# Altium schematic sheet Master.SchDoc(Master)
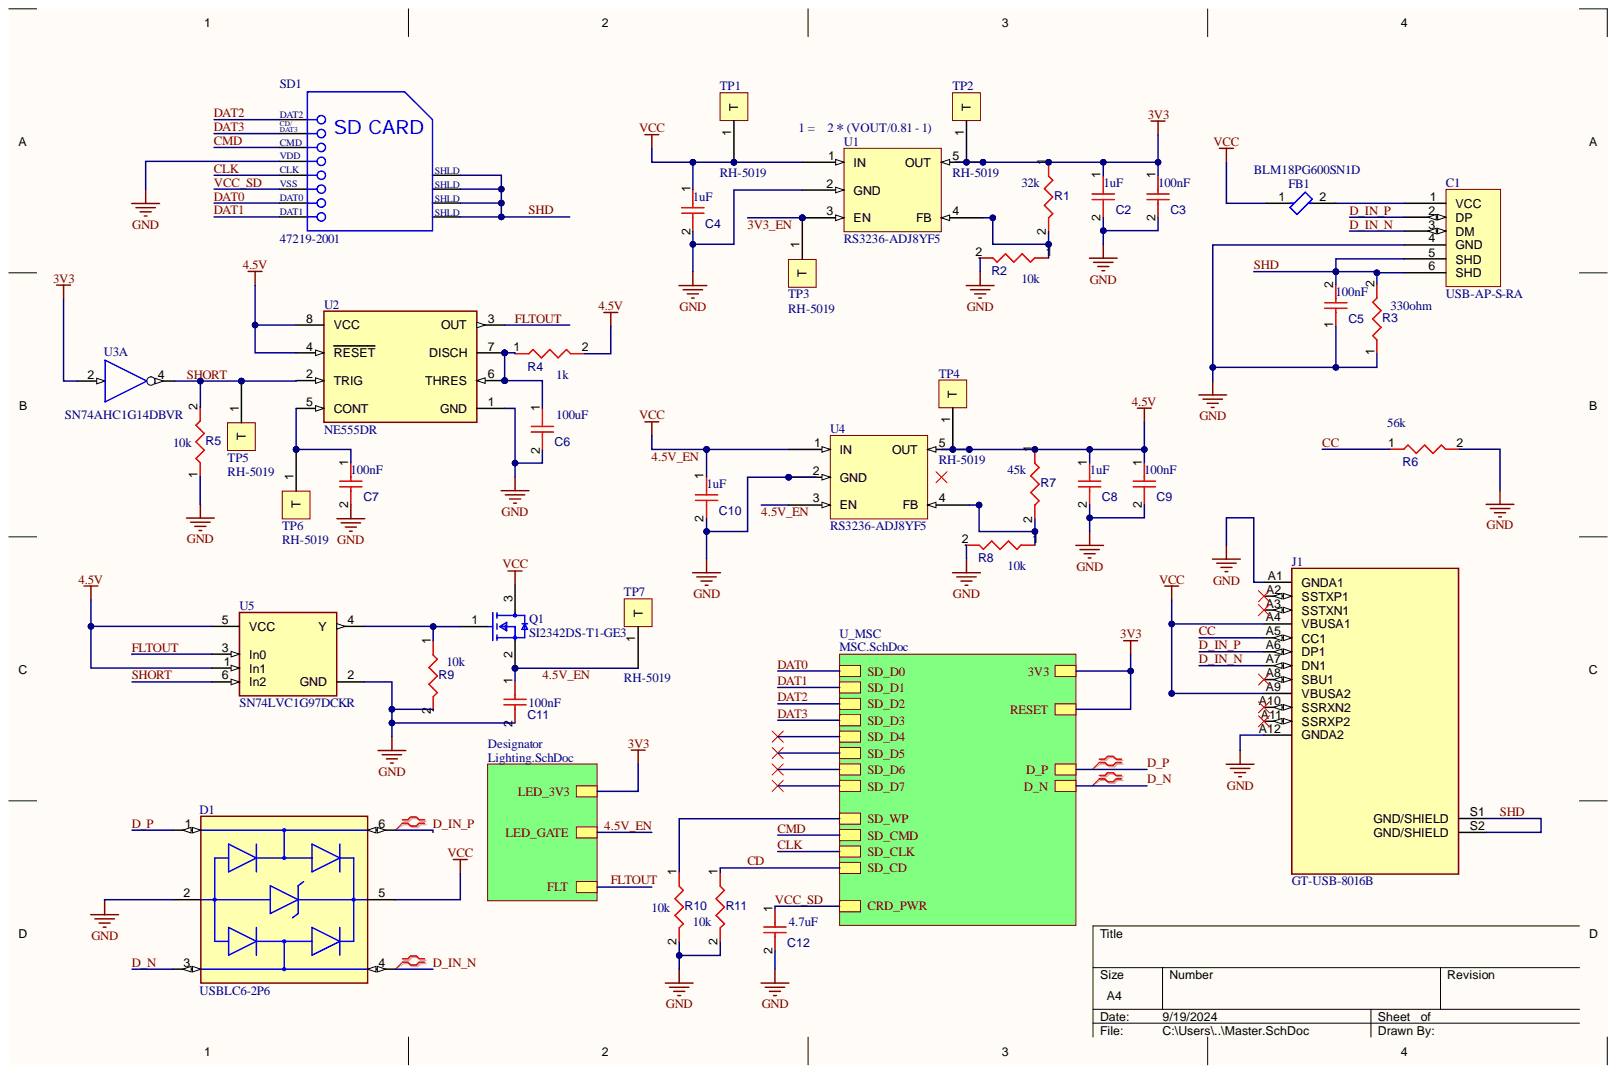
[diagram p0: Master.SchDoc]

## Parts
C1  USB-AP-S-RA
C2  value 1uF  (hidden comment: Capacitors,1uF)
C3  value 100nF  (hidden comment: Capacitors,100nF)
C4  value 1uF  (hidden comment: Capacitors,1uF)
C5  value 100nF  (hidden comment: Capacitors)
C6  value 100uF  (hidden comment: Capacitors,100uF)
C7  value 100nF  (hidden comment: Capacitors,100nF)
C8  value 1uF  (hidden comment: Capacitors,1uF)
C9  value 100nF  (hidden comment: Capacitors,100nF)
C10  value 1uF  (hidden comment: Capacitors,1uF)
C11  value 100nF  (hidden comment: Capacitors,100nF)
C12  value 4.7uF  (hidden comment: Capacitors,4.7uF)
D1  USBLC6-2P6  [FP-SOT-666-MFG]
FB1  BLM18PG600SN1D  [FP-BLM18-0_15-t0_8-IPC_B]
J1  GT-USB-8016B  [BELFUSE_SS-52400-002]
Q1  SI2342DS-T1-GE3  [FP-S-03946-MFG]
R1  value 32k  (hidden comment: Resistors,32k)
R2  value 10k  (hidden comment: Resistors,10k)
R3  value 330ohm  (hidden comment: Resistors)
R4  value 1k  (hidden comment: Resistors,1k)
R5  value 10k  (hidden comment: Resistors,10k)
R6  value 56k  (hidden comment: Resistors,56k)
R7  value 45k  (hidden comment: Resistors,32k)
R8  value 10k  (hidden comment: Resistors,10k)
R9  value 10k  (hidden comment: Resistors,10k)
R10  value 10k  (hidden comment: Resistors,10k)
R11  value 10k  (hidden comment: Resistors,10k)
SD1  47219-2001  [MOLX-47219-2001-8_V]
TP1  RH-5019  [RH-5019-Footprint-1]
TP2  RH-5019  [RH-5019-Footprint-1]
TP3  RH-5019  [RH-5019-Footprint-1]
TP4  RH-5019  [RH-5019-Footprint-1]
TP5  RH-5019  [RH-5019-Footprint-1]
TP6  RH-5019  [RH-5019-Footprint-1]
TP7  RH-5019  [RH-5019-Footprint-1]
U1  RS3236-ADJ8YF5  [SOT95P245X110-5N]
U2  NE555DR  [FP-D0008A-IPC_A]
U3  SN74AHC1G14DBVR  [DBV0005A_L]  (part A)
U4  RS3236-ADJ8YF5  [SOT95P245X110-5N]
U5  SN74LVC1G97DCKR  [FP-DCK0006A-MFG]

## Nets
3V3: C2.1 C3.1 R1.1 TP2.1 U1.5(OUT) U3.2
4.5V: C8.1 C9.1 R4.2 R7.1 TP4.1 U2.4(RESET) U2.8(VCC) U4.5(OUT) U5.1(In1) U5.5(VCC)
GND: C1.4(GND) C2.2 C3.2 C4.2 C5.1 C6.2 C7.2 C8.2 C9.2 C10.2 C11.2 C12.2 D1.2 J1.A1(GNDA1) J1.A12(GNDA2) R2.2 R3.1 R5.1 R6.2 R8.2 R9.2 R10.2 R11.2 SD1.4 U1.2(GND) U2.1(GND) U4.2(GND) U5.2(GND)
3V3_EN: TP3.1 U1.3(EN)
4.5V_EN: C4.1 C10.1 C11.1 D1.5 FB1.1 J1.A4(VBUSA1) J1.A9(VBUSA2) Q1.2 Q1.3 TP1.1 TP7.1 U1.1(IN) U4.1(IN) U4.3(EN)
CC: J1.A5(CC1) R6.1
CD: R11.1
CLK: SD1.5
CMD: SD1.3
D_IN_N: C1.3(DM) D1.4 J1.A7(DN1)
D_IN_P: C1.2(DP) D1.6 J1.A6(DP1)
D_N: D1.3
D_P: D1.1
DAT0: SD1.7
DAT1: SD1.8
DAT2: SD1.1
DAT3: SD1.2(SD_CARD)
FLTOUT: U2.3(OUT) U5.3(In0)
SHD: C1.5(SHD) C1.6(SHD) C5.2 J1.S1(GND/SHIELD) J1.S2(GND/SHIELD) R3.2 SD1.9 SD1.10 SD1.11 SD1.12
SHORT: R5.2 TP5.1 U2.2(TRIG) U3.4 U5.6(In2)
VCC_SD: C12.1 SD1.6
NetC1_1: C1.1(VCC) FB1.2
NetC6_1: C6.1 R4.1 U2.6(THRES) U2.7(DISCH)
NetC7_1: C7.1 TP6.1 U2.5(CONT)
NetJ1_A2: J1.A2(SSTXP1)
NetJ1_A3: J1.A3(SSTXN1)
NetJ1_A8: J1.A8(SBU1)
NetJ1_A10: J1.A10(SSRXN2)
NetJ1_A11: J1.A11(SSRXP2)
NetQ1_1: Q1.1 R9.1 U5.4(Y)
NetR1_2: R1.2 R2.1 U1.4(FB)
NetR7_2: R7.2 R8.1 U4.4(FB)
NetR10_1: R10.1
SD_D4:
SD_D5:
SD_D6:
SD_D7:

## Library data of the parts
C1 = CMP-002-00072-1: USB Type A Plug Single R/A PCB; Component Kind: Standard; Library Name: Brian Tan
C2-C12 = Capacitors: Capacitor; Component Kind: Standard; Component Type: Capacitors; Library Name: Brian Tan
D1 = CMP-12225-000058-1: TVS DIODE 5.25V 17V SOT666; Breakdown Voltage: 6V; Capacitance: 3.5pF; Direction: Unidirectional; Element Configuration: Dual; ESD Protection: Yes; Leakage Current: 150nA; Number of Bidirectional Channels: 2; Number of Elements: 1; Operating Supply Voltage: 5V; Peak Pulse Current: 5A; Test Current: 1mA; Case/Package: SOT-666; Clamping Voltage: 17V; Component Kind: Standard; Contact Plating: Tin; Depth: 1.3mm; Height: 0.6mm; Lead Free: Lead Free; Length: 1.7mm; Library Name: Altium Content Vault; Max Breakdown Voltage: 6V; Max Operating Temperature: 125°C; Max Reverse Leakage Current: 150nA; Min Breakdown Voltage: 6V; Min Operating Temperature: -40°C; Mount: Surface Mount; Number of Channels: 2; Number of Pins: 6; Package Quantity: 1; Packaging: Tape and Reel; Polarity: Unidirectional; Power Line Protection: Yes; Radiation Hardening: No; REACH SVHC: No SVHC; Reverse Breakdown Voltage: 6V; Reverse Standoff Voltage: 5V; Termination: SMD/SMT; Width: 1.3mm; Working Voltage: 5V
FB1 = CMP-0686-00575-3: Chip Ferrite Bead, 0603, 60Ω @ 100MHz, 0.1Ω, , 1A; Current Rating: 500mA; DC Resistance (DCR): 100mR; ESR (Equivalent Series Resistance): 60R; Material: Steel; Number of Circuits: 1; Shielding: Unshielded; Tolerance: 25%; Case Code (Imperial): 0603; Case Code (Metric): 1608; Case/Package: 0603; Component Kind: Standard; Core Material: Ferrite; Depth: 0.8mm; Frequency: 100MHz; Height: 0.8mm; Impedance: 60R; Lead Free: Lead Free; Length: 1.6mm; Library Name: Altium Content Vault; Max Current Rating: 500mA; Max DC Current: 500mA; Max Operating Temperature: 125°C; Min Operating Temperature: -55°C; Number of Lines: 1; Number of Pins: 2; Package Quantity: 1; Packaging: Tape and Reel; REACH SVHC: No SVHC; Resistance: 100mR; RoHS Compliant: Yes; Termination: SMD/SMT; Test Frequency: 100MHz; Width: 0.8mm
J1 = CMP-002-00071-2: USB C 2.0 Plug; Component Kind: Standard; Library Name: Brian Tan
Q1 = CMP-02550-000018-1: MOSFET N-CH 8V 6A SOT-23; Manufacturer: Vishay; Depletion Mode: False; Drain To Source Resistance: 0.014Ω; Gate Charge At Vgs: 10.5nC @ 4.5V; Gate To Source Voltage: 5V; Input Capacitace At Vds: 1070pF @ 4V; Max Forward Diode Voltage: 1.2V; Max Junction Temp: +150°C; Number Of Channels: 1; Power Dissipation: 1.3W; Pulse Drain Current: 30A; Reverse Recovery Charge: 17nC; Reverse Recovery Time: 40ns; Rthja Max: 75°C/W; Standoff Height: 0.01mm; Threshold Vgs Max: 0.8V; Threshold Vgs Min: 0.35V; Transconductance: 75S; Turn Off Delay Time: 65ns; Turn On Delay Time: 6ns; Typ Forward Diode Voltage: 0.82V; Automotive: No; Category: Trans; Component Kind: Standard; ComponentLink2Description: Datasheet; ComponentLink2URL: https://www.vishay.com/docs/63302/si2342ds.pdf; Continuous Drain Current: 6A; Device Class L1: Discrete Semiconductors; Device Class L2: Transistors; Device Class L3: MOSFETs; Digikey Description: MOSFET N-CH 8V 6A SOT-23; Drain To Source Breakdown Voltage: 8V; Drain To Source Voltage: 8V; Height: 1.12mm; Ipc Land Pattern Name: SOT95P237X100-3; Lead Free: Yes; Library Name: Altium Content Vault; Manufacturer Part Number: SI2342DS-T1-GE3; Mouser Description: MOSFET 8V 6A 2.5; Number Of N Channels: 1; Octopart: https://octopart.com/si2342ds-t1-ge3-vishay-45050957; Package: S-03946; RoHS: TRUE; Temperature: -55°C to +150°C; Temperature Range High: +150°C; Temperature Range Low: -55°C
R1-R11 = Resistors: Resistor; Component Kind: Standard; Component Type: Resistors; Library Name: Brian Tan
SD1 = CMP-2000-05245-1: MicroSD Card Connector, Hinge Type, -40 to 85 degC, 8-Pin SMD, RoHS, Tape and Reel; Brand: Molex; Current Rating: 500mA; Insertion - Extraction Style: Hinged; Number of Contacts: 8; Pitch: 1.09mm; Voltage Rating: 100VDC; Card Type: microSD; Component Kind: Standard; Contact Material: CopperAlloy; Datasheet URL: http://www.molex.com/pdm_docs/sd/472192001_sd.pdf; Datasheet Version: Rev. F, 06/2009; Factory Pack Quantity: 2400; Housing Material: Thermoplastic; Library Name: Altium Content Vault; Manufacturer: Molex; Manufacturer URL: http://www.molex.com/; Mounting Style: SMD/SMT; Packaging: Reel; Product: CardConnectors; Product Category: MemoryCardConnectors; RoHS: Details; Series: 47219; Termination Style: SMD
TP1-TP7 = CMP-00030-00001-2: PC Test Point; Component Kind: Standard; Library Name: Brian Tan
U1 U4 = CMP-019-00049-1: IC Reg Lin Pos Adj 500MA SOT23-5; Component Kind: Standard; Library Name: Brian Tan
U2 = CMP-1685-00007-2: IC OSC SGL TIMER 100KHZ 8-SOIC; High Level Output Current: -200mA; Low Level Output Current: 200mA; Max Supply Voltage: 16V; Min Supply Voltage: 4.5V; Number of Timers/Counters: 1; Operating Supply Current: 10mA; Quiescent Current: 15mA; Case/Package: SOIC; Component Kind: Standard; Contact Plating: Gold; Frequency: 100kHz; Height: 1.58mm; Lead Free: Lead Free; Length: 4.9mm; Library Name: Altium Content Vault; Max Operating Temperature: 70°C; Min Operating Temperature: 0°C; Mount: Surface Mount; Number of Pins: 8; Package Quantity: 2500; Packaging: Tape and Reel; Radiation Hardening: No; REACH SVHC: No SVHC; Weight: 0.002561oz; Width: 3.91mm
U3 = CMP-2000-07131-1: Single Schmitt-Trigger Inverter Gate, 10.6 ns max, 2 to 5.5 V, -40 to 125 degC, 5-Pin SOT23 (DBV), Green (RoHS & no Sb/Br), Tape and Reel; Manufacturer: Texas Instruments; Base Number: 74; Logic Case Style: SOT-23; Logic Function Number: 741G14; Logic IC Base Number: 74114; Logic IC Family: AHC; Logic IC Function: SingleInverterwithSchmittTrigger; No. of Gates: 1; No. of Pins: 5; Output Current: 8mA; Supply Voltage: 5.5V; Supply Voltage Min: 2V; Supply Voltage Range: 2Vto5.5V; SVHC: NoSVHC(19-Dec-2012); Component Kind: Standard; Datasheet URL: http://www.ti.com/lit/ds/symlink/sn74ahc1g14.pdf; Datasheet Version: Rev. Q, 09/2015; IC Generic Number: 74AHC1G14; Library Name: Altium Content Vault; Logic Type: Inverter; Manufacturer URL: http://www.ti.com/; Mounting Technology: SurfaceMount; No. of Inputs: 1; Operating Temperature Max: 85°C; Operating Temperature Min: -40°C; Operating Temperature Range: -40°Cto+85°C; Output Current Max: 8mA; Package / Case: SOT-23; Package Description: 5-Pin Small Outline Transistor, Body 2.9 x 1.6 mm, Pitch 0.95 mm; Package Reference: DBV0005A; Package URL: http://www.ti.com/lit/ml/mpds018l/mpds018l.pdf; Supply Voltage Max: 5.5V; Termination Type: SMD
U5 = CMP-0859-00500-4: IC CONFIG MULT-FUNC GATE SC70-6; Manufacturer: Texas Instruments; Max Supply Voltage: 5.5V; Min Supply Voltage: 1.5V; Nominal Supply Current: 10uA; Number Of Circuits: 1; Number Of Inputs: 3; Propagation Delay: 6.1ps; Standoff Height: 0mm; Ambient Temperature Range High: +125°C; Ambient Temperature Range Low: -40°C; Automotive: No; Category: IC; Component Kind: Standard; ComponentLink2Description: Datasheet; ComponentLink2URL: http://www.ti.com/lit/ds/symlink/sn74lvc1g97.pdf; Device Class L1: Integrated Circuits (ICs); Device Class L2: Logic ICs; Device Class L3: Logic Gates; Digikey Description: IC CONFIG MULT-FUNC GATE SC70-6; Height: 1.1mm; Lead Free: Yes; Library Name: Altium Content Vault; Manufacturer Part Number: SN74LVC1G97DCKR; Mouser Description: Logic Gates CONFIGURABLE MULTI FUNCTN GATE; Number Of Outputs: 1; Octopart: https://octopart.com/sn74lvc1g97dckr-texas+instruments-464843; Package: DCK0006A; RoHS: TRUE; Temperature: -40°C to +125°C; Temperature Range High: +125°C; Temperature Range Low: -40°C
